# Altium schematic sheet CrashDAQSensors.SchDoc
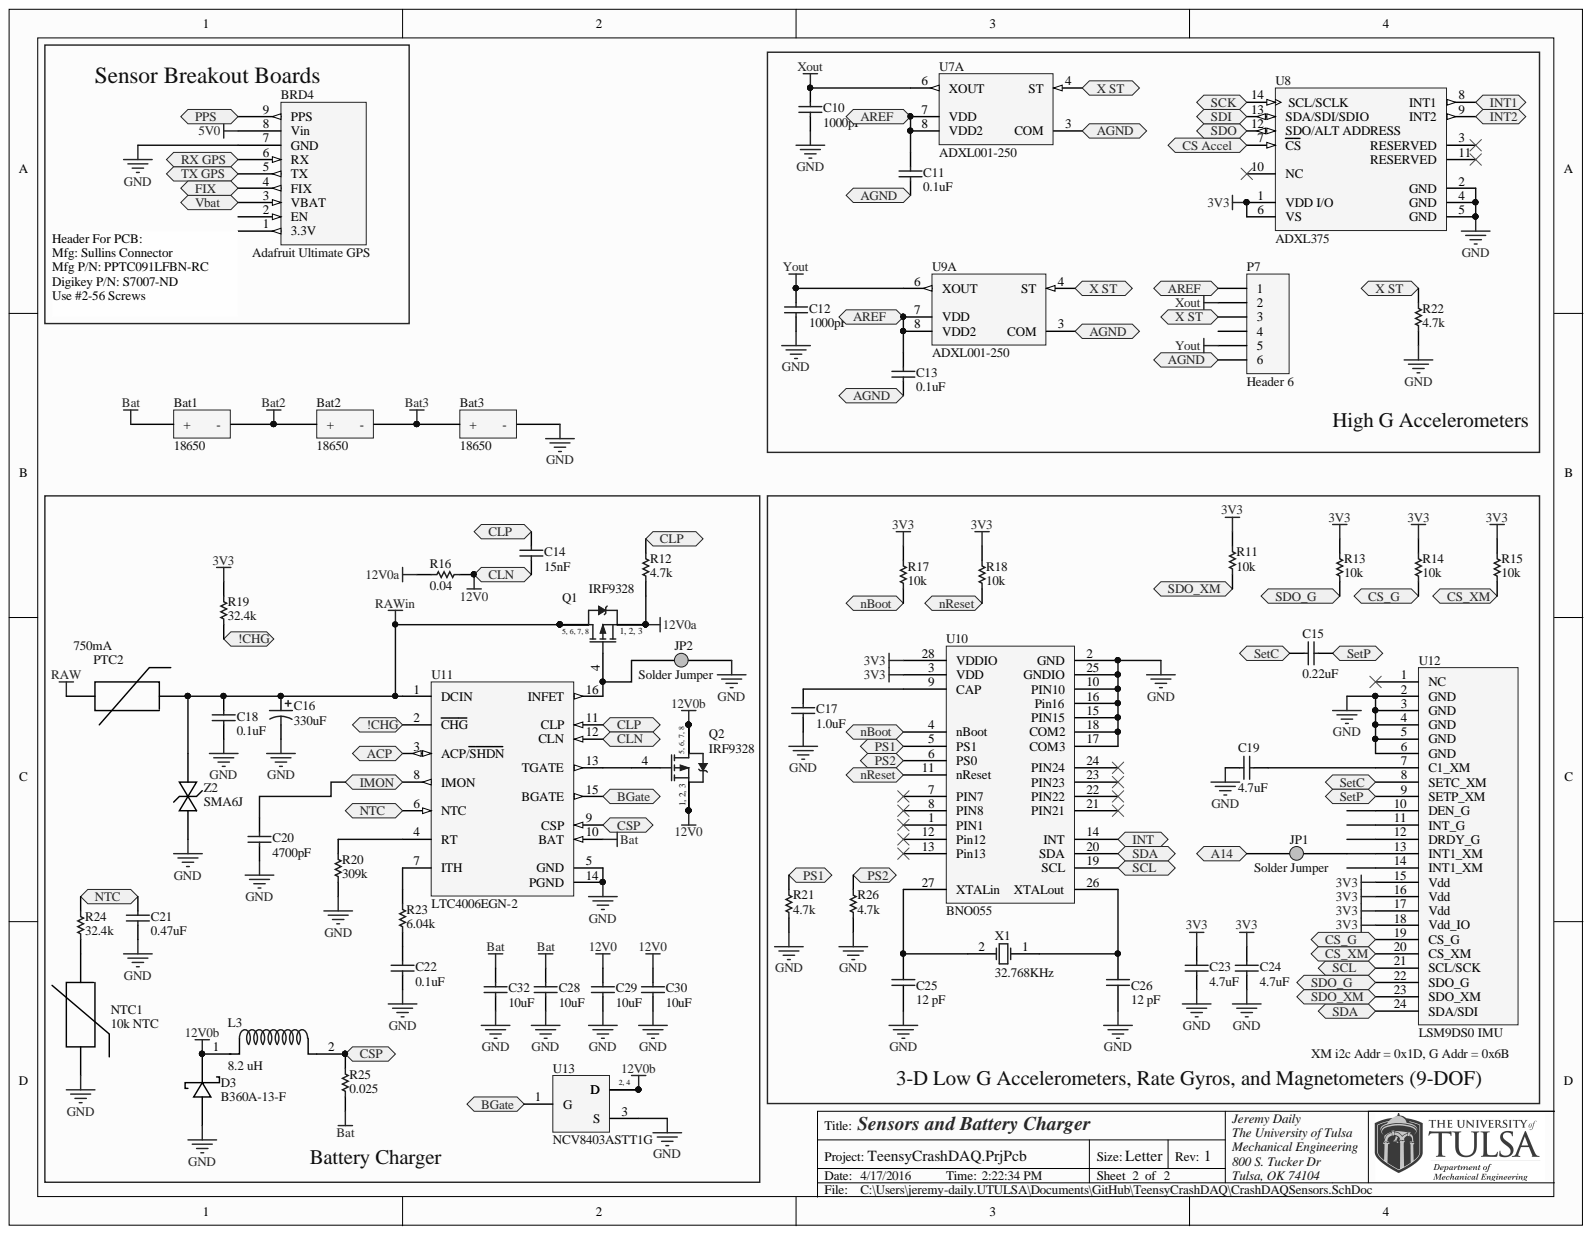
[diagram p0: CrashDAQSensors.SchDoc]

## Parts
Bat1  18650  [18650 Holder]
Bat2  18650  [18650 Holder]
Bat3  18650  [18650 Holder]
BRD4  Adafruit Ultimate GPS  Manufacturer: Adafruit  [GPS]
C10  1000pF  [0603]
C11  0.1uF  [0603]
C12  1000pF  [0603]
C13  0.1uF  [0603]
C14  15nF  [0603]
C15  0.22uF  [0603]
C16  330uF  [Elec. Cap 5 x 10mm]
C17  1.0uF  [0603]
C18  0.1uF  [0603]
C19  4.7uF  [1206]
C20  4700pF  [0603]
C21  0.47uF  [0603]
C22  0.1uF  [0603]
C23  4.7uF  [1206]
C24  4.7uF  [1206]
C25  12 pF  [0603]
C26  12 pF  [0603]
C28  10uF  [1206]
C29  10uF  [1206]
C30  10uF  [1206]
C32  10uF  [1206]
D3  B360A-13-F  [SMA with A and K]
JP1  Solder Jumper  [Solder Jumper]
JP2  Solder Jumper  [Solder Jumper]
L3  8.2 uH  [Inductor - 1045]
NTC1  10k NTC  [0603]
P7  Header 6  [HDR1X6]
PTC2  750mA  [2920]
Q1  IRF9328  [SOIC-8]
Q2  IRF9328  [SOIC-8]
R11  10k  [0603]
R12  4.7k  [0603]
R13  10k  [0603]
R14  10k  [0603]
R15  10k  [0603]
R16  0.04  [2818]
R17  10k  [0603]
R18  10k  [0603]
R19  32.4k  [0603]
R20  309k  [0603]
R21  4.7k  [0603]
R22  4.7k  [0603]
R23  6.04k  [0603]
R24  32.4k  [0603]
R25  0.025  [2818]
R26  4.7k  [0603]
U7  ADXL001-250  [ADI-E-8-1_V]  (part A)
U8  ADXL375  [ADI-CC-14-1_V]
U9  ADXL001-250  [ADI-E-8-1_V]  (part A)
U10  BNO055  [BNO055 LGA]
U11  LTC4006EGN-2  [LT-GN-16_N]
U12  LSM9DS0 IMU  [LGA 4x4x1 mm 24-lead]
U13  NCV8403ASTT1G  [ONSC-SOT-223-4-318E-04_V]
X1  32.768KHz  [XTAL 2 pad]
Z2  SMA6J  [SMA]

## Ports
!CHG, A14, ACP, AGND, AREF, BGATE, CLN, CLP, CS ACCEL, CS_G, CS_XM, CSP, FIX, IMON, INT, INT1, INT2, NBOOT, NRESET, NTC, PPS, PS1, PS2, RX GPS, SCK, SCL, SDA, SDI, SDO, SDO_G, SDO_XM, SETC, SETP, TX GPS, VBAT, X ST

## Nets
3V3: C23.2 C24.2 R11.2 R13.2 R14.2 R15.2 R17.2 R18.2 R19.2 U8.1(VDD_I/O) U8.6(VS) U10.3(VDD) U10.28(VDDIO) U12.15(Vdd) U12.16(Vdd) U12.17(Vdd) U12.18(Vdd_IO)
5V0: BRD4.8(Vin)
12V0A: Q1.1 Q1.2 Q1.3 R12.2 R16.1
12V0B: D3.2 L3.1 Q2.5 Q2.6 Q2.7 Q2.8 U13.2(D) U13.4
BAT: Bat1.1 C28.2 C32.2 R25.2 U11.10(BAT)
BAT2: Bat1.2 Bat2.1
BAT3: Bat2.2 Bat3.1
GND: Bat3.2 BRD4.7(GND) C10.1 C12.1 C16.2 C17.1 C18.1 C19.2 C20.1 C21.1 C22.1 C23.1 C24.1 C25.1 C26.1 C28.1 C29.1 C30.1 C32.1 D3.1 JP2.2 NTC1.1 R20.2 R21.1 R22.1 R26.1 U8.2(GND) U8.4(GND) U8.5(GND) U10.2(GND) U10.10(PIN10) U10.15(PIN15) U10.16(Pin16) U10.17(COM3) U10.18(COM2) U10.25(GNDIO) U11.5(GND) U11.14(PGND) U12.2(GND) U12.3(GND) U12.4(GND) U12.5(GND) U12.6(GND) U13.3(S) Z2.2
RAW: PTC2.1
RAWIN: C16.1 C18.2 PTC2.2 Q1.5 Q1.6 Q1.7 Q1.8 U11.1(DCIN) Z2.1
XOUT: C10.2 P7.2 U7.6(XOUT)
YOUT: C12.2 P7.5 U9.6(XOUT)
12V0: C14.1 C29.2 C30.2 Q2.1 Q2.2 Q2.3 R16.2 U11.12(CLN) ; port CLN
!CHG: R19.1 U11.2(CHG) ; port !CHG
A14: JP1.1 ; port A14
ACP: U11.3(ACP/SHDN) ; port ACP
AGND: C11.1 C13.1 P7.6 U7.3(COM) U9.3(COM) ; port AGND
AREF: C11.2 C13.2 P7.1 U7.7(VDD) U7.8(VDD2) U9.7(VDD) U9.8(VDD2) ; port AREF
CLP: C14.2 R12.1 U11.11(CLP) ; port CLP
CS_G: R14.1 U12.19(CS_G) ; port CS_G
CS_XM: R15.1 U12.20(CS_XM) ; port CS_XM
CSP: L3.2 R25.1 U11.9(CSP) ; port CSP
FIX: BRD4.4(FIX) ; port FIX
IMON: C20.2 U11.8(IMON) ; port IMON
INT: U10.14(INT) ; port INT
INT1: U8.8(INT1) ; port INT1
INT2: U8.9(INT2) ; port INT2
NTC: C21.2 R24.1 U11.6(NTC) ; port NTC
PPS: BRD4.9(PPS) ; port PPS
PS1: R21.2 U10.5(PS1) ; port PS1
PS2: R26.2 U10.6(PS0) ; port PS2
RX GPS: BRD4.6(RX) ; port RX GPS
SCK: U8.14(SCL/SCLK) ; port SCK
SCL: U10.19(SCL) U12.21(SCL/SCK) ; port SCL
SDA: U10.20(SDA) U12.24(SDA/SDI) ; port SDA
SDI: U8.13(SDA/SDI/SDIO) ; port SDI
SDO: U8.12(SDO/ALT_ADDRESS) ; port SDO
SDO_G: R13.1 U12.22(SDO_G) ; port SDO_G
SDO_XM: R11.1 U12.23(SDO_XM) ; port SDO_XM
TX GPS: BRD4.5(TX) ; port TX GPS
X ST: P7.3 R22.2 U7.4(ST) U9.4(ST) ; port X ST
NetBRD4_3: BRD4.3(VBAT) ; port VBAT
NetC15_1: C15.1 U12.9(SETP_XM) ; port SETP
NetC15_2: C15.2 U12.8(SETC_XM) ; port SETC
NetC17_2: C17.2 U10.9(CAP)
NetC19_1: C19.1 U12.7(C1_XM)
NetC22_2: C22.2 R23.2
NetC25_2: C25.2 U10.27(XTALin) X1.2
NetC26_2: C26.2 U10.26(XTALout) X1.1
NetJP1_2: JP1.2 U12.13(INT1_XM)
NetJP2_1: JP2.1 Q1.4 U11.16(INFET)
NetNTC1_2: NTC1.2 R24.2
NetQ2_4: Q2.4 U11.13(TGATE)
NetR17_1: R17.1 U10.4(nBoot) ; port NBOOT
NetR18_1: R18.1 U10.11(nReset) ; port NRESET
NetR20_1: R20.1 U11.4(RT)
NetR23_1: R23.1 U11.7(ITH)
NetU8_7: U8.7(CS) ; port CS ACCEL
NetU11_15: U11.15(BGATE) U13.1(G) ; port BGATE

Not connected: BRD4 1(3.3V) 2(EN); P7 4; U8 3(RESERVED) 10(NC) 11(RESERVED); U10 1(PIN1) 7(PIN7) 8(PIN8) 12(Pin12) 13(Pin13) 21(PIN21) 22(PIN22) 23(PIN23) 24(PIN24); U12 1(NC) 10(DEN_G) 11(INT_G) 12(DRDY_G) 14(INT1_XM)

## Library data of the parts
Bat1-Bat3 = Battery: HOLDER FOR 18650 BATTERY; Manufacturer: Keystone Electronics; PartNumber: 1043P; Component Kind: Standard; Library Name: SSS Integrated Library.IntLib; Supplier 1: Digi-Key; Supplier Part Number 1: 36-1043P-ND
BRD4 = GPS - Adafruit: PartNumber: 746; Component Kind: Standard; Description: Adafruit Ultimate GPS; Library Name: SSS Integrated Library.IntLib; Supplier 1: Digi-Key; Supplier Part Number 1: 1528-1153-ND
C10 C12 = Cap: 1000pF ±10% 50V X7R Ceramic Capacitor -55°C ~ 125°C Surface Mount, MLCC 0603 (1608 Metric) 0.063" L x 0.031" W (1.60mm x 0.80mm); Manufacturer: Murata Electronics North America; PartNumber: GRM188R71H102KA01D; Component Kind: Standard; Library Name: SSS Schematic Library.SchLib; Signal Integrity: Cap; Simulation: CAP; Supplier 1: Digi-Key; Supplier Part Number 1: 490-1494-1-ND
C11 C13 C18 C22 = Cap: 0.10µF ±10% 50V X7R Ceramic Capacitor -55°C ~ 125°C Surface Mount, MLCC 0603 (1608 Metric) 0.063" L x 0.031" W (1.60mm x 0.80mm); Manufacturer: Murata Electronics North America; PartNumber: GRM188R71H104KA93D; Component Kind: Standard; Library Name: SSS Schematic Library.SchLib; Signal Integrity: Cap; Simulation: CAP; Supplier 1: Digi-Key; Supplier Part Number 1: 490-1519-1-ND
C14 = Cap: CAP CER 0.015UF 50V X7R 0603; Manufacturer: Samsung Electro-Mechanics America, Inc.; PartNumber: CL10B153KB8SFNC; Component Kind: Standard; Library Name: SSS Schematic Library.SchLib; Signal Integrity: Cap; Simulation: CAP; Supplier 1: Digi-Key; Supplier Part Number 1: 1276-1967-1-ND
C15 = Cap: 0.22µF ±10% 25V X7R Ceramic Capacitor -55°C ~ 125°C Surface Mount, MLCC 0603 (1608 Metric) 0.063" L x 0.031" W (1.60mm x 0.80mm); Manufacturer: Murata Electronics North America; PartNumber: GRM188R71E224KA88D; Component Kind: Standard; Library Name: SSS Schematic Library.SchLib; Signal Integrity: Cap; Simulation: CAP; Supplier 1: Digi-Key; Supplier Part Number 1: 490-3290-1-ND
C16 = SMD Elec: CAP ALUM 330UF 20% 50V RADIAL; Manufacturer: Panasonic Electronic Components; PartNumber: ECA-1HM331; Component Kind: Standard; Library Name: Capacitors.IntLib; Supplier 1: Digi-Key; Supplier Part Number 1: P5184-ND
C17 = Cap: 1µF ±10% 10V X5R Ceramic Capacitor -55°C ~ 85°C Surface Mount, MLCC 0603 (1608 Metric) 0.063" L x 0.031" W (1.60mm x 0.80mm); Manufacturer: Murata Electronics North America; PartNumber: GRM188R61A105KA61D; Component Kind: Standard; Library Name: SSS Schematic Library.SchLib; Signal Integrity: Cap; Simulation: CAP; Supplier 1: Digi-Key; Supplier Part Number 1: 490-1543-1-ND
C19 C23 C24 = Cap: 4.7µF ±10% 50V X7R Ceramic Capacitor -55°C ~ 125°C Surface Mount, MLCC 1206; Manufacturer: Samsung Electro-Mechanics America, Inc.; PartNumber: CL31B475KBHNNNE; Component Kind: Standard; Library Name: SSS Schematic Library.SchLib; Signal Integrity: Cap; Simulation: CAP; Supplier 1: Digi-Key; Supplier Part Number 1: 1276-2789-1-ND
C20 = Cap: Multilayer Ceramic Capacitors MLCC - SMD/SMT 0603 4700pF 50volts X7R 10%; Manufacturer: Murata Electronics North America; PartNumber: GRM188R71H472KA01D; Component Kind: Standard; Library Name: SSS Schematic Library.SchLib; Signal Integrity: Cap; Simulation: CAP; Supplier 1: Digi-Key; Supplier Part Number 1: 490-1506-1-ND
C21 = Cap: 0.47µF ±10% 25V X7R Ceramic Capacitor -55°C ~ 125°C Surface Mount, MLCC 0603 (1608 Metric) 0.063" L x 0.031" W (1.60mm x 0.80mm); Manufacturer: Murata Electronics North America; PartNumber: GRM188R71E474KA12D; Component Kind: Standard; Library Name: SSS Schematic Library.SchLib; Signal Integrity: Cap; Simulation: CAP; Supplier 1: Digi-Key; Supplier Part Number 1: 490-3291-1-ND
C25 C26 = Cap: CAP CER 12PF 50V NP0 0603; Manufacturer: Murata Electronics North America; PartNumber: GRM1885C1H120JA01D; Component Kind: Standard; Library Name: SSS Integrated Library.IntLib; Supplier 1: Digi-Key; Supplier 2: Mouser; Supplier Part Number 1: 490-1405-1-ND; Supplier Part Number 2: 81-GRM39C120J50
C28-C30 C32 = Cap: 10µF -20%, +80% 16V Y5V (F) Ceramic Capacitor -30°C ~ 85°C Surface Mount, MLCC 120; Manufacturer: Yageo; PartNumber: CC1206ZKY5V7BB106; Component Kind: Standard; Library Name: SSS Schematic Library.SchLib; Signal Integrity: Cap; Simulation: CAP; Supplier 1: Digi-Key; Supplier Part Number 1: 311-1376-1-ND
D3 = Schottky Diode: DIODE SCHOTTKY 60V 3A SMA; Manufacturer: Diodes Incorporated; Component Kind: Standard; Library Name: SSS Integrated Library.IntLib; PartNumber: B360A-13-F; Supplier 1: Digi-Key; Supplier Part Number 1: B360A-FDICT-ND
JP1 JP2 = Solder Jumper: DNP; Component Kind: Standard; Library Name: SSS Integrated Library.IntLib
L3 = Inductor: FIXED IND 8.2UH 5.05A 28.6 MOHM; Manufacturer: Wurth Electronics Inc; PartNumber: 7447797820; Component Kind: Standard; Library Name: SSS Integrated Library.IntLib; Supplier 1: Digi-Key; Supplier Part Number 1: 732-2213-1-ND
NTC1 = NTC: THERMISTOR 10K OHM NTC 0603 SMD; Manufacturer: Murata Electronics North America; PartNumber: NCP18XH103F03RB; Component Kind: Standard; Library Name: SSS Integrated Library.IntLib; Supplier 1: Digi-Key; Supplier Part Number 1: 490-4800-1-ND
P7 = Header 6: Header, 6-Pin; Component Kind: Standard; Library Name: Miscellaneous Connectors.IntLib; Signal Integrity: Connector
PTC2 = PTC: PTC RESETBL 60V 750MA SMD 2920; Manufacturer: Littelfuse; PartNumber: 2920L075/60MR; Component Kind: Standard; Library Name: SSS Integrated Library.IntLib; Supplier 1: Digi-Key; Supplier Part Number 1: F5633CT-ND
Q1 Q2 = IRF9328 P-FET: MOSFET P-CH 30V 12A 8-SOIC; Manufacturer: Infineon Technologies Americas Corp.; PartNumber: IRF9328PBF; Component Kind: Standard; Library Name: SSS Integrated Library.IntLib; Supplier 1: Digi-Key; Supplier Part Number 1: IRF9328PBF-ND
R11 R13-R15 R17 R18 = Chip Resistor: 10k Ohm ±1% 0.1W, 1/10W Surface Mount Resistor Thick Film ±100ppm/°C 0603; Manufacturer: Panasonic Electronic Components; PartNumber: ERJ-3EKF1002V; Component Kind: Standard; Library Name: SSS Schematic Library.SchLib; Supplier 1: Digi-Key; Supplier Part Number 1: P10.0KHCT-ND
R12 R21 R22 R26 = Chip Resistor: 4.7k Ohm ±1% 0.1W, 1/10W Surface Mount Resistor Thick Film ±100ppm/°C 0603; Manufacturer: Panasonic Electronic Components; PartNumber: ERJ-3EKF4701V; Component Kind: Standard; Library Name: SSS Schematic Library.SchLib; Supplier 1: Digi-Key; Supplier Part Number 1: P4.70KHCT-ND
R16 = Chip Resistor: 0.04 Ohm ±1% 7W Surface Mount Resistor Metal Element ±75ppm/°C 2818; Manufacturer: Vishay Dale; PartNumber: WSHM2818R0400FEA; Component Kind: Standard; Library Name: SSS Schematic Library.SchLib; Supplier 1: Digi-Key; Supplier Part Number 1: 541-2632-1-ND
R19 R24 = Chip Resistor: 32.4k Ohm ±1% 0.1W, 1/10W Surface Mount Resistor Thick Film ±100ppm/°C 0603; Manufacturer: Panasonic Electronic Components; PartNumber: ERJ-3EKF3242V; Component Kind: Standard; Library Name: SSS Schematic Library.SchLib; Supplier 1: Digi-Key; Supplier Part Number 1: P32.4KHCT-ND
R20 = Chip Resistor: RES SMD 309K OHM 1% 1/10W 0603; Manufacturer: Panasonic Electronic Components; PartNumber: ERJ-3EKF3093V; Component Kind: Standard; Library Name: SSS Schematic Library.SchLib; Supplier 1: Digi-Key; Supplier Part Number 1: P309KHCT-ND
R23 = Chip Resistor: 6.04k Ohm ±1% 0.1W, 1/10W Surface Mount Resistor Thick Film ±100ppm/°C 0603; Manufacturer: Stackpole Electronics Inc.; PartNumber: RMCF0603FT6K04; Component Kind: Standard; Library Name: SSS Schematic Library.SchLib; Supplier 1: Digi-Key; Supplier Part Number 1: RMCF0603FT6K04CT-ND
R25 = Chip Resistor: 0.025 Ohm ±1% 7W Surface Mount Resistor Metal Element ±75ppm/°C 2818; Manufacturer: Vishay Dale; PartNumber: WSHM2818R0250FEA; Component Kind: Standard; Library Name: SSS Schematic Library.SchLib; Supplier 1: Digi-Key; Supplier Part Number 1: 541-2629-1-ND
U7 U9 = AD22279-A: IC ACCELEROMETER 250G 8CLCC; Manufacturer: Analog Devices; PartNumber: ADXL001-250BEZ; Component Kind: Standard; Supplier 1: Digi-Key; Supplier 2: Mouser; Supplier Part Number 1: ADXL001-250BEZ-ND; Supplier Part Number 2: 584-AD22279-A; Library Name: Analog Devices MEMS Inertial Sensors.IntLib
U8 = ADXL343BCCZ: +/- 200G IC ACCEL SPI/I2C 3AX 14LGA; Manufacturer: Analog Devices; PartNumber: ADXL375BCCZ-RL7; Component Kind: Standard; Supplier 1: Digi-Key; Supplier Part Number 1: ADXL375BCCZ-RL7CT-ND; Library Name: Analog Devices MEMS Inertial Sensors.IntLib
U10 = BNO055: IMUs - Inertial Measurement Units Absolute Orientation 9-Axis Sensor; Manufacturer: Bosch Sensortec; Component Kind: Standard; Library Name: SSS Integrated Library.IntLib; PartNumber: BNO055; Supplier 1: Mouser; Supplier Part Number 1: 262-BNO055
U11 = LTC4006EGN-2: 4 A, High Efficiency, Standalone Li-Ion Battery Charger, 6 to 28 V Vin, 16-pin SOP (GN-16), -40 to 85 degC; Manufacturer: Linear Technology; PartNumber: LTC4006EGN-2#PBF; Component Kind: Standard; Library Name: Linear Technology Power Management Battery Management.IntLib; Supplier 1: Digi-Key; Supplier Part Number 1: LTC4006EGN-2#PBF-ND
U12 = LSM9DS0 IMU: MOD ACCELEROMETER 3D 24LGA; Manufacturer: STMicroelectronics; PartNumber: LSM9DS0TR; Component Kind: Standard; Library Name: SSS Integrated Library.IntLib; Supplier 1: Digi-Key; Supplier Part Number 1: 497-13902-1-ND
U13 = NCV8403ASTT1G: Self-Protected Low Side Driver with Temperature and Current Limit, 4-Pin SOT-223, Pb-Free, Tape and Reel; Manufacturer: On Semiconductor; Component Kind: Standard; Library Name: ON Semi Protected MOSFETs.IntLib; PartNumber: NCV8403ASTT1G; Supplier 1: Digi-Key; Supplier 2: Mouser; Supplier Part Number 1: NCV8403ASTT1GOSCT-ND; Supplier Part Number 2: 863-NCV8403ASTT1G
X1 = Crystal Oscillator: Crystal 32.7680kHz 20ppm 12.5pF 70 kOhm -40°C - 85°C Surface Mount 2-SMD; Manufacturer: ECS Inc.; PartNumber: ECS-.327-12.5-39-TR; Component Kind: Standard; Library Name: SSS Integrated Library.IntLib; Supplier 1: Digi-Key; Supplier 2: Mouser; Supplier Part Number 1: XC1658CT-ND; Supplier Part Number 2: 520-.327-12.5-39-TR
Z2 = Zener - Bidirectional: TVS DIODE 24VWM 38.9VC SMA; Manufacturer: Micro Commercial Co; PartNumber: SMA6J24CA-TP; Component Kind: Standard; Library Name: SSS Schematic Library.SchLib; Supplier 1: Digi-Key; Supplier Part Number 1: SMA6J24CA-TPMSCT-ND
